# Altium schematic sheet HLib-I2CDevices_r1.SchDoc
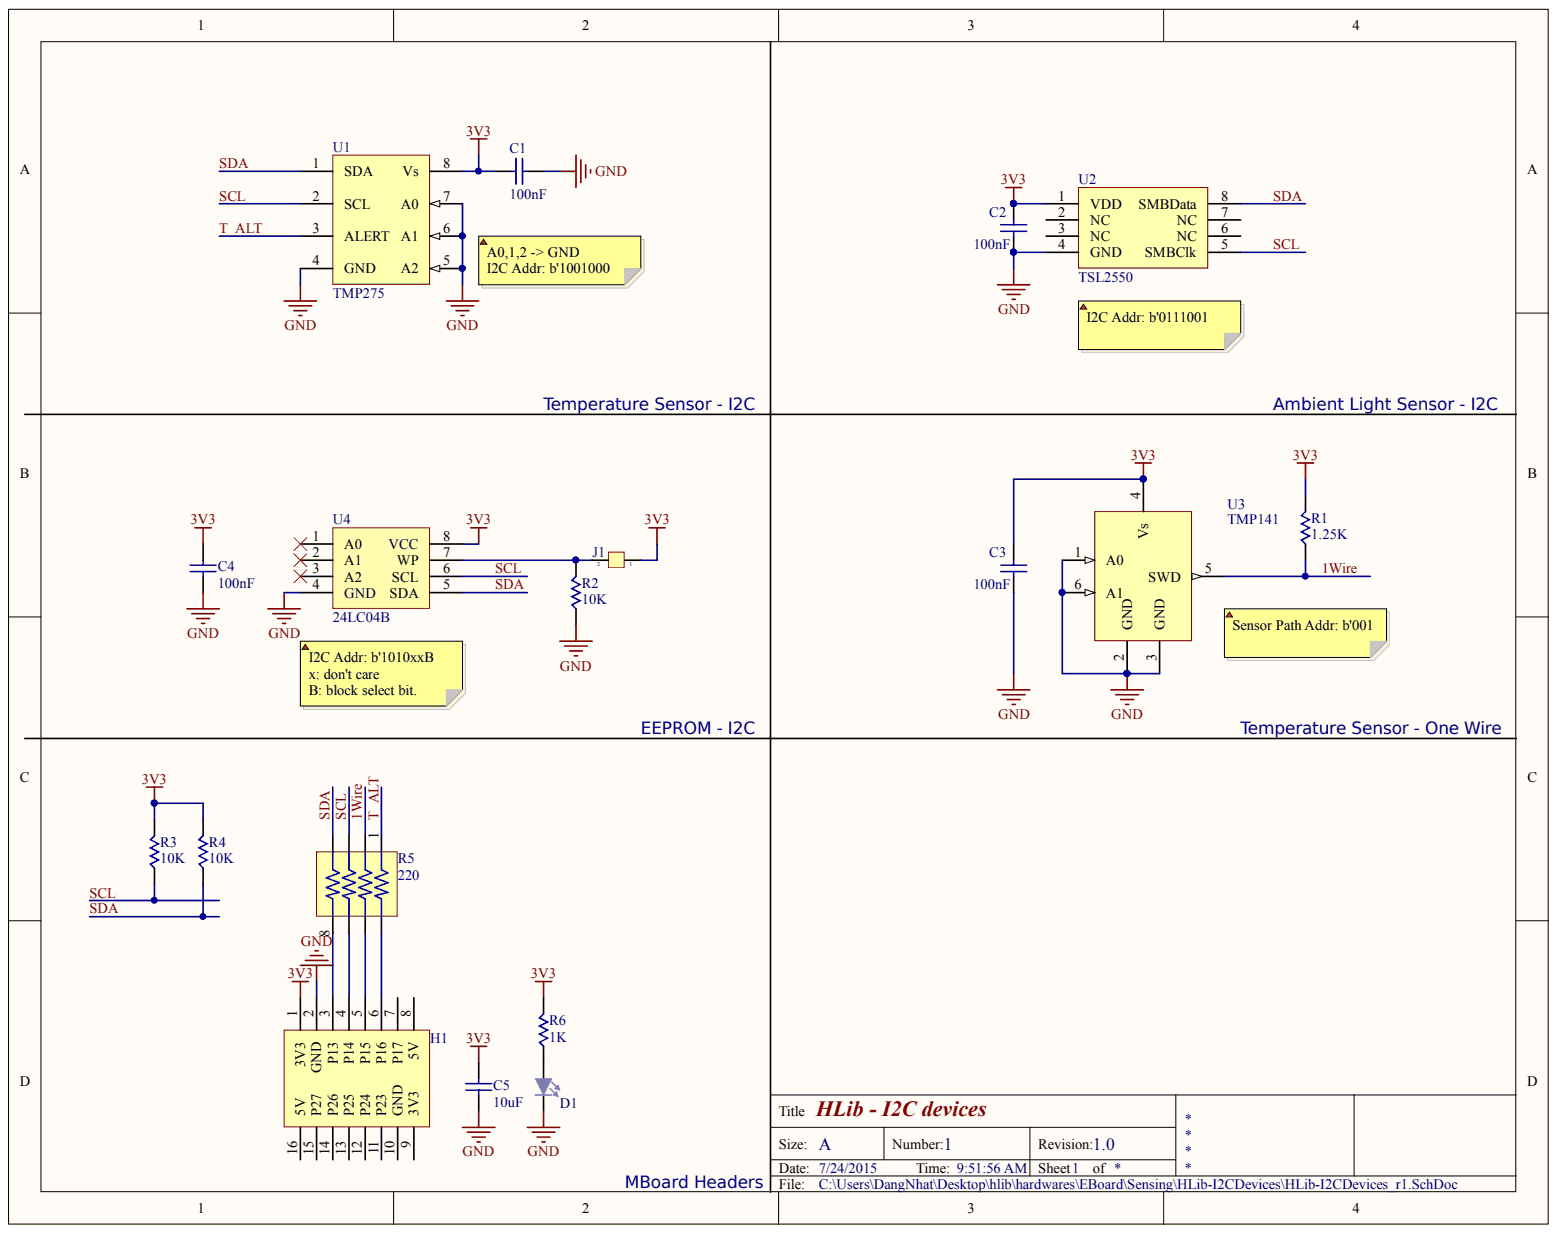
[diagram p0: HLib-I2CDevices_r1.SchDoc]

## Parts
C1  value 100nF  [SM_0805_C_CERAMIC]  (hidden comment: C_Ceramic)
C2  value 100nF  [SM_0805_C_CERAMIC]  (hidden comment: C_Ceramic)
C3  value 100nF  [SM_0805_C_CERAMIC]  (hidden comment: C_Ceramic)
C4  value 100nF  [SM_0805_C_CERAMIC]  (hidden comment: C_Ceramic)
C5  value 10uF  [SM_0805_C_CERAMIC]  (hidden comment: C_Ceramic)
D1  LED  [SM_0805_LED]  (value not drawn)
H1  MBoard1_BH_DualPorts  [MBoard1_BH_DualPorts]  (value not drawn)
J1  Jumper 2  [HD_MALE_2_100mil_straight]  (value not drawn)
R1  R  value 1.25K  [SM_0805_R]
R2  R  value 10K  [SM_0805_R]
R3  R  value 10K  [SM_0805_R]
R4  R  value 10K  [SM_0805_R]
R5  220  [RA4]
R6  R  value 1K  [SM_0805_R]
U1  TMP275  [SO-8]
U2  TSL2550  [SO-8]
U3  TMP141  [SOT23-6]
U4  24LC04B  [SO-8]

## Nets
3V3: C1.2 C2.1 C3.1 C4.1 C5.2 H1.1(3V3) J1.1 R1.2 R3.2 R4.2 R6.2 U1.8(Vs) U2.1(VDD) U3.4(Vs) U4.8(VCC)
GND: C1.1 C2.2 C3.2 C4.2 C5.1 D1.2 H1.2(GND) R2.1 U1.4(GND) U1.5(A2) U1.6(A1) U1.7(A0) U2.4(GND) U3.1(A0) U3.2(GND) U3.3(GND) U3.6(A1) U4.4(GND)
1WIRE: R1.1 R5.3 U3.5(SWD)
SCL: R3.1 R5.5 U1.2(SCL) U2.5(SMBClk) U4.6(SCL)
SDA: R4.1 R5.7 U1.1(SDA) U2.8(SMBData) U4.5(SDA)
T_ALT: R5.1 U1.3(ALERT)
NetD1_1: D1.1 R6.1
NetH1_3: H1.3(P13) R5.8
NetH1_4: H1.4(P14) R5.6
NetH1_5: H1.5(P15) R5.4
NetH1_6: H1.6(P16) R5.2
NetJ1_2: J1.2 R2.2 U4.7(WP)

Not connected: H1 7(P17) 8(5V) 9(3V3) 10(GND) 11(P23) 12(P24) 13(P25) 14(P26) 15(P27) 16(5V); U2 2(NC) 3(NC) 6(NC) 7(NC); U4 1(A0) 2(A1) 3(A2)

## Library data of the parts
C1-C5 = C_Ceramic: Non-polarity ceramic capacitor; Component Kind: Standard; LatestRevisionDate: 17-Jul-2002; LatestRevisionNote: Re-released for DXP Platform.; Library Name: HLib_discrete_sch.SchLib; PackageDescription: Radial Cap, Thru-Hole; 2 Leads; 0.3 in Pin Spacing; PackageReference: RAD-0.3; Published: 8-Jun-2000; Publisher: Altium Limited
J1 = JP 2: Value: JP 2; Component Kind: Standard; Description: Jumper 2; Library Name: VioletofSun.SchLib
R1-R4 R6 = R: Resistor; Component Kind: Standard; LatestRevisionDate: 29-May-2009; LatestRevisionNote: IPC-7351 Footprint Added.; Library Name: HLib_discrete_sch.SchLib; PackageDescription: Chip Resistor; PackageReference: J1-0603; Published: 8-Jun-2000; Publisher: Altium Limited
R5 = RA4: Component Kind: Standard; Library Name: Nhat_Lib.SchLib
U1 = TMP75C: Component Kind: Standard; Library Name: Nhat_Lib.SchLib
U4 = 24Cxx: Standard 2-Wire Bus Interface Serial EEPROM; Value: DIP 8; Value: 24Cxx; Component Kind: Standard; PCB3D: DIP8; Copyright by: VanDungEvn; Library Name: VioletofSun.SchLib
D1 = LED: Component Kind: Standard; Description: LED; LatestRevisionDate: 08-Jul-2005; LatestRevisionNote: Mech Layer 1 removed.; Library Name: HLib_discrete_sch.SchLib; PackageDescription: LED; 2 Leads; PackageReference: LED-1; Published: 8-Jun-2000; Publisher: Altium Limited
H1 = MBoard1_BH_DualPorts: Component Kind: Standard; Library Name: Nhat_Lib.SchLib
U2 = TSL2550: Component Kind: Standard; Library Name: Nhat_Lib.SchLib
U3 = TMP141: Component Kind: Standard; Library Name: Nhat_Lib.SchLib
